# Altium schematic sheet VFD_alpha_Sheet0.SchDoc(VFD_alpha_Sheet0)
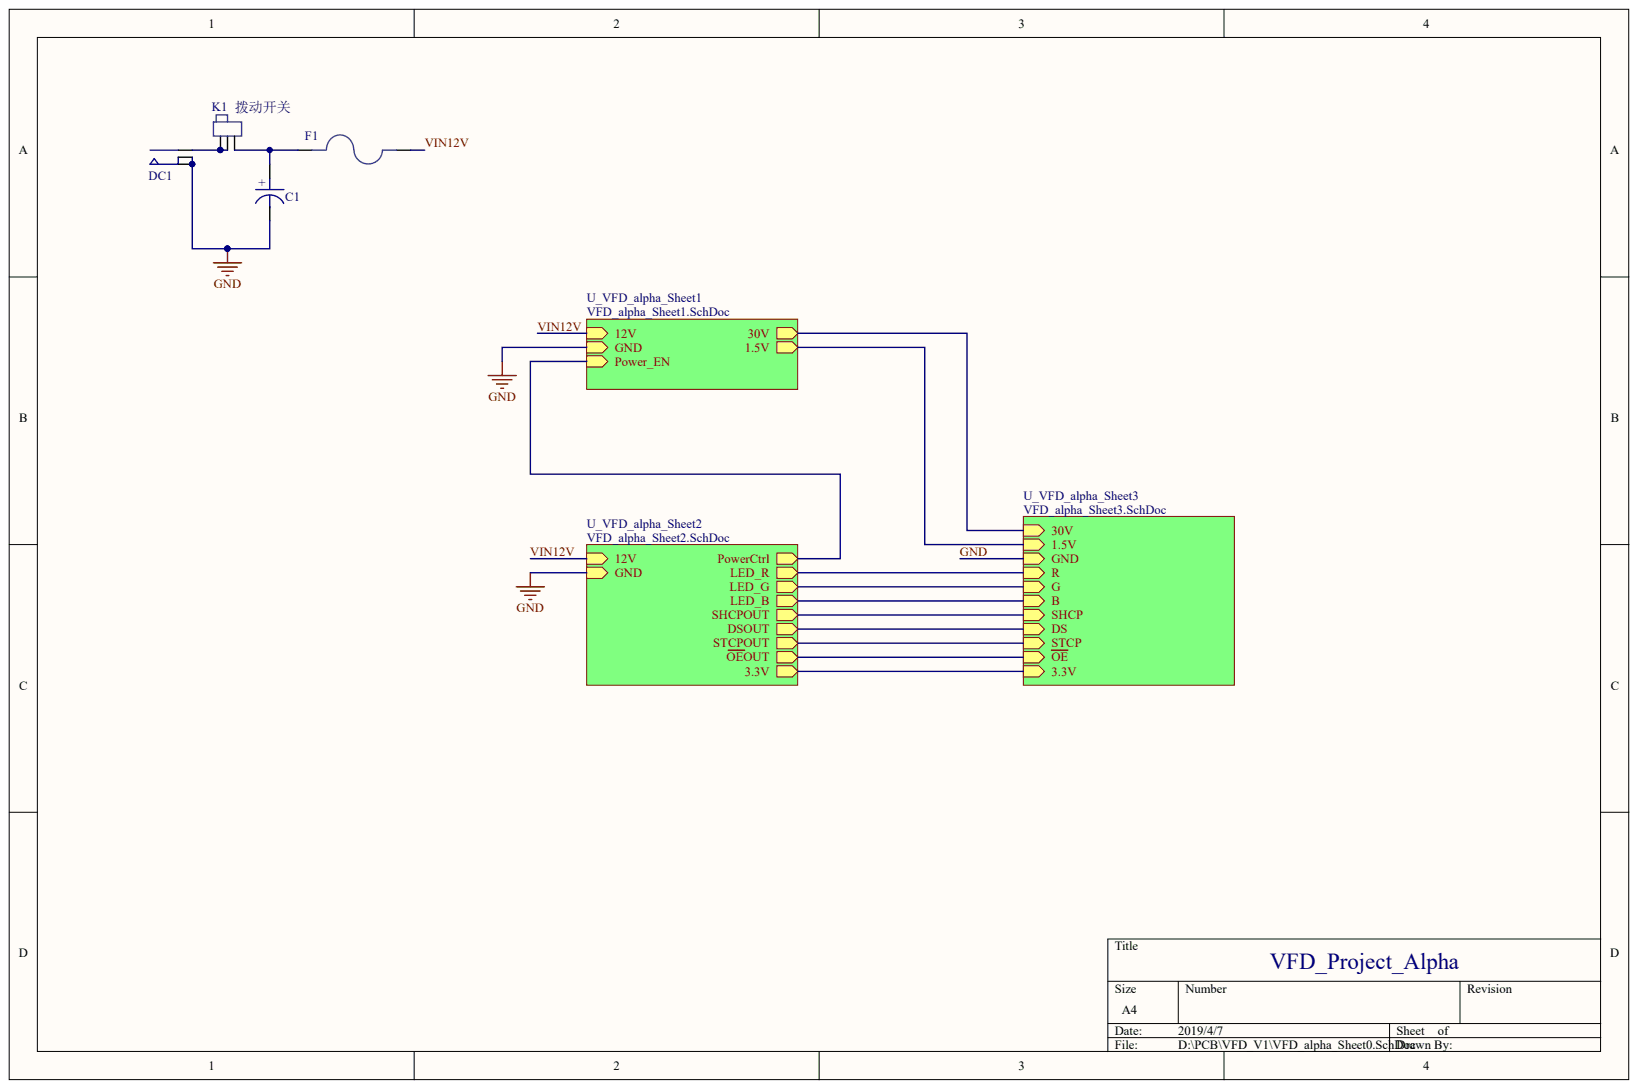
[diagram p0: VFD_alpha_Sheet0.SchDoc]

## Parts
C1  CAP_D5  (value not drawn)
DC1  DC-5.5  (value not drawn)
F1  保险丝  (value not drawn)
K1  拨动开关

## Nets
GND: C1.2 DC1.2 DC1.3
VIN12V: F1.2
NetC1_1: C1.1 F1.1 K1.3
NetDC1_1: DC1.1 K1.1 K1.2
1.5V:
3.3V:
30V:
~{OE}OUT:
DSOUT:
LED_B:
LED_G:
LED_R:
POWER_EN:
SHCPOUT:
STCPOUT:
